# Altium schematic sheet AnalogSignalPath.SchDoc(AnalogSignalPath) (continued)
## Library data of the parts (continued)
U5-U8 = TL071HIDBVR: Single, 40-V, 5-MHz, 4-mV offset voltage, 20-V/µs, In to V+ op amp with -40°C to 125°C operation 5-SOT-23 -40 to 125; Manufacturer: Texas Instruments; Manufacturer Lifecycle Status: ACTIVE; Number of Channels: 1; Case/Package: SOT-23; Category: Amplifiers - Op Amps, Buffer, Instrumentation; Component Kind: Standard; ComponentLink1Description: https://sigma.octopart.com:443/177332311/technical_drawing/Texas-Instruments-TL071HIDBVR.pdf?src-supplier=Texas+Instruments; ComponentLink1URL: https://sigma.octopart.com:443/177332311/technical_drawing/Texas-Instruments-TL071HIDBVR.pdf?src-supplier=Texas+Instruments; ComponentLink2Description: https://octopart.com:443/opatz8j6/c1?t=NeYbaGdGpDtfp13XBVX-_BIREoTJ0JJL4-vxQ-0MnCY4H9YG30NxCGE6_JL9myIRaTxs_TDMvtb6gUEdJaVSuB2D_Vy915w2Kx3McrBcvpBy3VtpOGFIdAWCI7S8wjPY9GIThFqsTt4Qlmo34mDI744b8fbTvAWm5dHUgA50mTRWRptzvU0Y0LKLWxytkrpq1B0YEw0PjBiGCR_gVoreAsDTlSM-L9NTJH5rz8lWVrRij6wgpRte34-I3FlMeFHAuf3eL2xOEmHTlOEnwn_wRUwwPKomNcVroqc295muhSB74pMqiL0F49CddwZMltWnAuHOeMq8DjpYhVeSu-tyDG8Aeb4sxj0AR6XENBzWLh6jY_euby9Ykfdr_g0OUYOEyNHkYVM&src-supplier=Texas+Instruments; ComponentLink2URL: https://octopart.com:443/opatz8j6/c1?t=NeYbaGdGpDtfp13XBVX-_BIREoTJ0JJL4-vxQ-0MnCY4H9YG30NxCGE6_JL9myIRaTxs_TDMvtb6gUEdJaVSuB2D_Vy915w2Kx3McrBcvpBy3VtpOGFIdAWCI7S8wjPY9GIThFqsTt4Qlmo34mDI744b8fbTvAWm5dHUgA50mTRWRptzvU0Y0LKLWxytkrpq1B0YEw0PjBiGCR_gVoreAsDTlSM-L9NTJH5rz8lWVrRij6wgpRte34-I3FlMeFHAuf3eL2xOEmHTlOEnwn_wRUwwPKomNcVroqc295muhSB74pMqiL0F49CddwZMltWnAuHOeMq8DjpYhVeSu-tyDG8Aeb4sxj0AR6XENBzWLh6jY_euby9Ykfdr_g0OUYOEyNHkYVM&src-supplier=Texas+Instruments; ComponentLink3Description: https://sigma.octopart.com:443/175570406/technical_drawing/Texas-Instruments-TL071HIDBVR.pdf?src-supplier=Texas+Instruments; ComponentLink3URL: https://sigma.octopart.com:443/175570406/technical_drawing/Texas-Instruments-TL071HIDBVR.pdf?src-supplier=Texas+Instruments; ComponentLink4Description: https://sigma.octopart.com:443/169406034/technical_drawing/Texas-Instruments-TL071HIDBVR.pdf?src-supplier=Texas+Instruments; ComponentLink4URL: https://sigma.octopart.com:443/169406034/technical_drawing/Texas-Instruments-TL071HIDBVR.pdf?src-supplier=Texas+Instruments; ComponentLink5Description: https://sigma.octopart.com:443/155939316/technical_drawing/Texas-Instruments-TL071HIDBVR.pdf?src-supplier=Texas+Instruments; ComponentLink5URL: https://sigma.octopart.com:443/155939316/technical_drawing/Texas-Instruments-TL071HIDBVR.pdf?src-supplier=Texas+Instruments; ComponentLink6Description: http://sigma.octopart.com:80/reach_statement/Texas-Instruments-company-64.pdf?src-supplier=Compliance+Statements; ComponentLink6URL: http://sigma.octopart.com:80/reach_statement/Texas-Instruments-company-64.pdf?src-supplier=Compliance+Statements; ComponentLink7Description: http://sigma.octopart.com:80/conflict_mineral_statement/Texas-Instruments-company-63.pdf?src-supplier=Compliance+Statements; ComponentLink7URL: http://sigma.octopart.com:80/conflict_mineral_statement/Texas-Instruments-company-63.pdf?src-supplier=Compliance+Statements; Height: 1.45mm; Length: 2.9mm; Lifecycle Status: Production; Manufacturer Part Number: TL071HIDBVR; Pins: 5; Pricing: 1=0.29, 10=0.2, 25=0.1768, 100=0.1519, 250=0.13996, 500=0.13278, 1000=0.12684 (USD); RoHS: Compliant; Stock: 17939; Supplier: DigiKey; Supplier Part Number: 296-TL071HIDBVRCT-ND; Thickness: 1.2mm; Width: 1.6mm; Library Name: Tremelo_Pedal.SchLib
